# Altium schematic sheet Raijin_Connectors.SchDoc
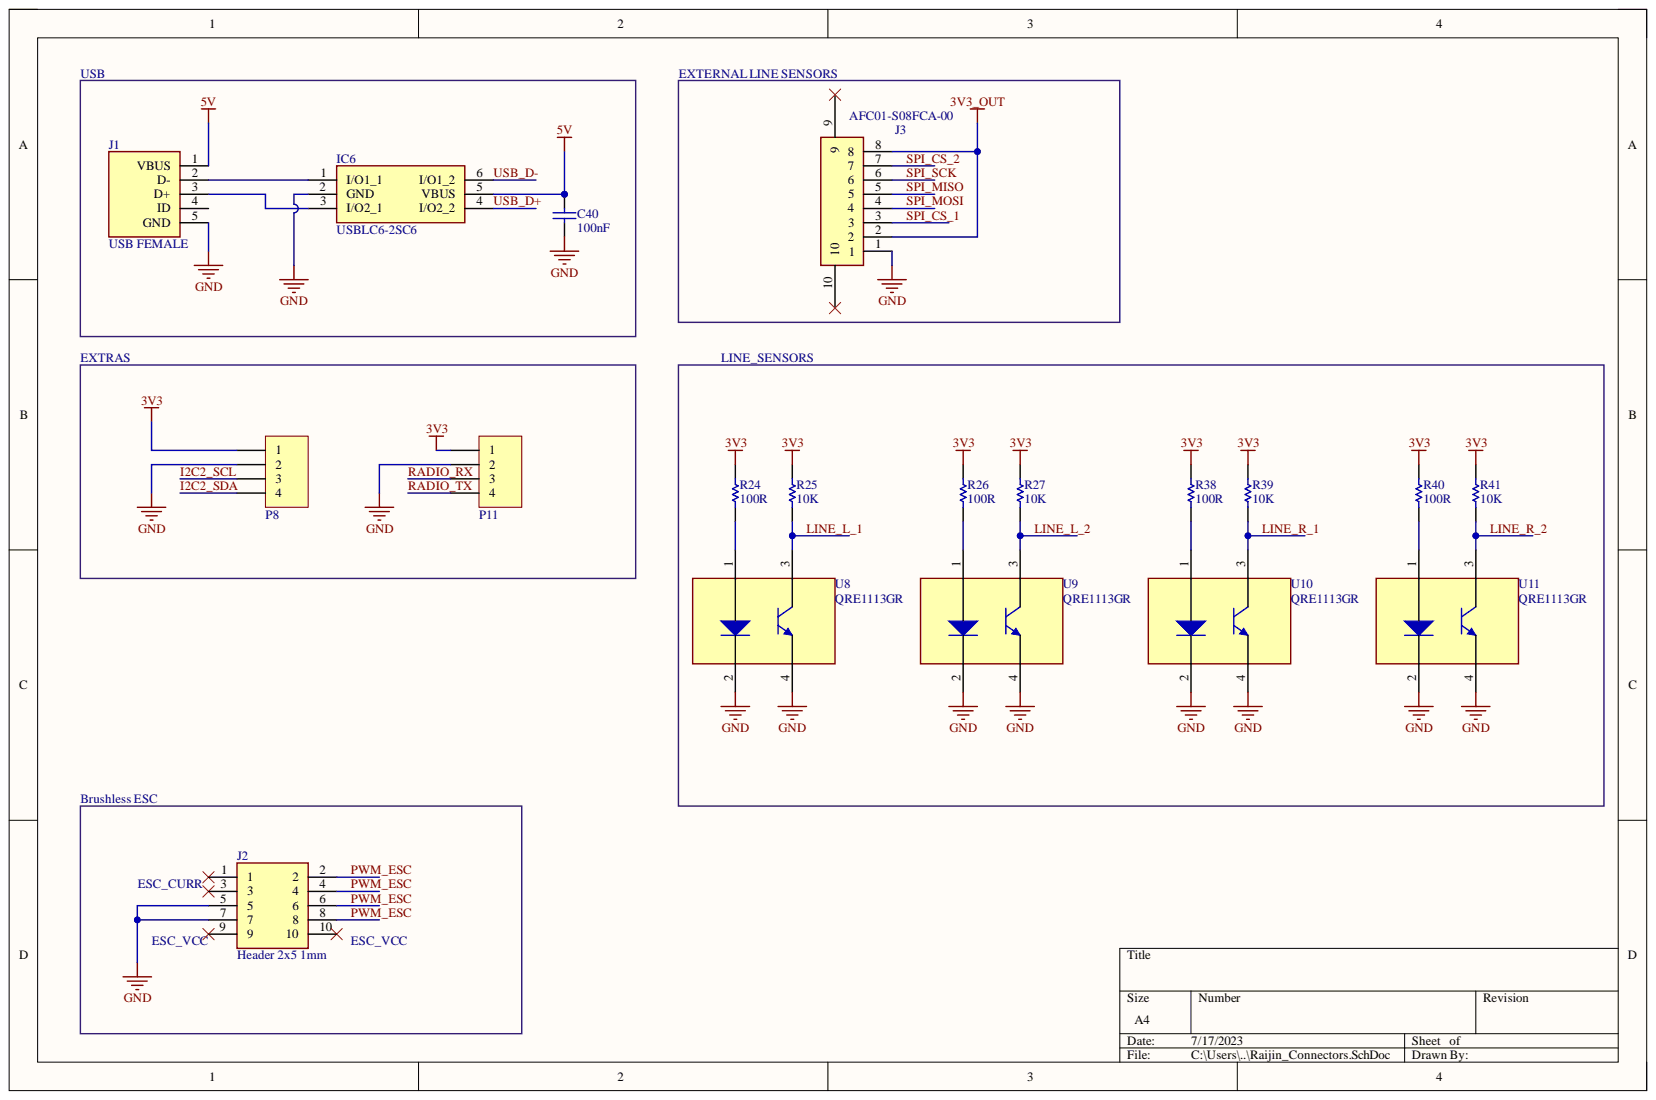
[diagram p0: Raijin_Connectors.SchDoc]

## Parts
C40  100nF  [Capacitor-0603]
IC6  USBLC6-2SC6  [SOT95P280X145-6N]
J1  USB FEMALE  [47346-0001]
J2  Header 2x5 1mm  [ESC-JHEMCU - CONNECTOR]
J3  AFC01-S08FCA-00  [FPC-SMD_P0.50-8P_FGS-XJ-H2.0]
P8  Header 1x4  [HDR1X4]  (value not drawn)
P11  Header 1x4  [HDR1X4]  (value not drawn)
R24  100R  [RESISTOR 0603]
R25  10K  [RESISTOR 0603]
R26  100R  [RESISTOR 0603]
R27  10K  [RESISTOR 0603]
R38  100R  [RESISTOR 0603]
R39  10K  [RESISTOR 0603]
R40  100R  [RESISTOR 0603]
R41  10K  [RESISTOR 0603]
U8  QRE1113GR  [QRE1113GR]
U9  QRE1113GR  [QRE1113GR]
U10  QRE1113GR  [QRE1113GR]
U11  QRE1113GR  [QRE1113GR]

## Nets
3V3: P8.1 P11.1 R24.2 R25.2 R26.2 R27.2 R38.2 R39.2 R40.2 R41.2
3V3_OUT: J3.2 J3.8
5V: C40.2 IC6.5(VBUS) J1.1(VBUS)
GND: C40.1 IC6.2(GND) J1.5(GND) J2.5 J2.7 J3.1 P8.2 P11.2 U8.2 U8.4 U9.2 U9.4 U10.2 U10.4 U11.2 U11.4
I2C2_SCL: P8.3
I2C2_SDA: P8.4
LINE_L_1: R25.1 U8.3
LINE_L_2: R27.1 U9.3
LINE_R_1: R39.1 U10.3
LINE_R_2: R41.1 U11.3
PWM_ESC: J2.2 J2.4 J2.6 J2.8
RADIO_RX: P11.3
RADIO_TX: P11.4
SPI_CS_1: J3.3
SPI_CS_2: J3.7
SPI_MISO: J3.5
SPI_MOSI: J3.4
SPI_SCK: J3.6
USB_D+: IC6.4(I/O2_2)
USB_D-: IC6.6(I/O1_2)
NetIC6_1: IC6.1(I/O1_1) J1.2(D-)
NetIC6_3: IC6.3(I/O2_1) J1.3(D+)
NetR24_1: R24.1 U8.1
NetR26_1: R26.1 U9.1
NetR38_1: R38.1 U10.1
NetR40_1: R40.1 U11.1

Not connected: J1 4(ID); J2 1 3 9 10; J3 9 10

## Library data of the parts
C40 = CAP 100NF 50V: Capacitor Cer 100nF 50V; Manufacturer Part Number: IMP14925; Capacitance: 0.1uF; Capacitance Tolerance: +80%, -20%; Capacitor Terminals: Radial Leaded; Dielectric Characteristic: Y5; Product Range: MCFY Series; SVHC: No SVHC (15-Jun-2015); Voltage Rating: 50; Capacitance Tolerance ±: +80%, -20%; Component Kind: Standard; ComponentLink1Description: http://loja.multcomercial.com.br/capacitor-ceramico-disco-100kpf-50v-104-100k-100nf-0-1uf-cod-1351.html; ComponentLink1URL: http://loja.multcomercial.com.br/capacitor-ceramico-disco-100kpf-50v-104-100k-100nf-0-1uf-cod-1351.html; Lead Spacing: 5; Manufacturer: -; Operating Temperature Max: 85; Operating Temperature Min: -25; Operating Temperature Range: -25°C to +85°C<!--FANDB--><div class="features-and-benefits-container"><div class="features-and-benefits-description"><span class="features-benefits-value">The MCFY series from Multicomp are radial leaded ceramic disc capacitors. The capacitance values ranges from 6800pF to 0.22?F.</span></div><div class="features-and-benefits-list"><ul class="features-and-benefits-list-list"><li class="features-and-benefits-list-item">Large capacitance in small size</li><li class="features-and-benefits-list-item">Rated voltage of 50V</li><li class="features-and-benefits-list-item">80%/-20% tolerance</li><li class="features-and-benefits-list-item">Operating temperature range -25°C to +85; Packaging: Each; Pricing 1: 1=0.10 (BRL); RoHS: YES; Stock 1: 115809; Supplier 1: Mult Comercial; Supplier Part Number 1: Capacitor Cerâmico Disco 100Kpf/50V (104/100K/100nF/0.1uF) cód. 1351; Library Name: RaijinLib.SchLib
IC6 = USBLC6-2SC6: Integrated Circuit; Manufacturer_Name: STMicroelectronics; Arrow Part Number: USBLC6-2SC6; Arrow Price/Stock: https://www.arrow.com/en/products/usblc6-2sc6/stmicroelectronics?region=nac; Component Kind: Standard; Datasheet Link: http://www.st.com/st-web-ui/static/active/en/resource/technical/document/datasheet/CD00050750.pdf; Height: 1.45mm; Manufacturer_Part_Number: USBLC6-2SC6; Mouser Part Number: 511-USBLC6-2SC6; Mouser Price/Stock: https://www.mouser.co.uk/ProductDetail/STMicroelectronics/USBLC6-2SC6?qs=po45yt2pPpu%2FhNIlwQdTlg%3D%3D; Library Name: RaijinLib.SchLib
J1 = USB FEMALE: Connector; Manufacturer_Name: Molex; Component Kind: Standard; Datasheet Link: http://www.molex.com/pdm_docs/sd/473460001_sd.pdf; Manufacturer_Part_Number: 47346-0001; Mouser Part Number: 538-47346-0001; Mouser Price/Stock: https://www.mouser.co.uk/ProductDetail/Molex/47346-0001?qs=c2CV6XM0DweJBWaSeyWeCw%3D%3D; Library Name: RaijinLib.SchLib
J2 = Header 2x5 1mm: Connector; Component Kind: Standard; Library Name: RaijinLib.SchLib
J3 = AFC01-S08FCA-00: FFC/FPC Connectors.   SMD,P=0.5mm, 8 pins; Manufacturer_Name: Joshuo; Component Kind: Standard; Datasheet Link: https://datasheet.lcsc.com/lcsc/2304140030_JUSHUO-AFC01-S08FCA-00_C262657.pdf; LCSC Link: https://www.lcsc.com/product-detail/FFC-FPC-Connectors_JUSHUO-AFC01-S08FCA-00_C262657.html; Manufacturer_Part_Number: AFC01-S08FCA-00; Library Name: RaijinLib.SchLib
P8 P11 = Header 1x4: Headers & Wire Housings 40Pin 2mm Spacing Single Row Hdrs, 5pc; Manufacturer Part Number: IMP10327; Brand: Cromax; Mounting Angle: Vertical; Number of Positions: 40 Position; Accessory Type: -; Category: Headers & Wire Housings; Component Kind: Standard; ComponentLink1Description: http://loja.multcomercial.com.br/barra-de-pinos-simples-1x40-macho-bmo40-1e.html; ComponentLink1URL: http://loja.multcomercial.com.br/barra-de-pinos-simples-1x40-macho-bmo40-1e.html; Contact Gender: Pin (Male); Contact Plating: Gold; Contact Type: -; Manufacturer: Cromax; Minimum Order Quantity: 1; Mounting Style: Straight Pin; Number of Rows: 1 Row; Pitch: 2 mm; Pricing 1: 1=1.00, 5=0.20 (BRL); Product: Headers; Product Category: Accessories; Reeling: False; RoHS: RoHS Compliant; RoHS - Mouser: Y; Row Spacing: -; Stock 1: 14; Supplier 1: Mult Comercial; Supplier Part Number 1: Barra de Pinos Simples 1x40 Macho BMO40-1E; Termination Style: Through Hole; Type: Breakaway; Library Name: RaijinLib.SchLib
R24 R26 R38 R40 = RES 100R 5%: Manufacturer: Ty-Ohm; Manufacturer Part Number: CR25; Power (Watts): 0.25W, 1/4W; Temperature Coefficient: 0/ -500ppm/°C; Tolerance: ±5%; Category: Resistors; Component Kind: Standard; ComponentLink1Description: http://loja.multcomercial.com.br/resistor-de-1-4-de-watt-cr25-5-de-tolerancia.html; ComponentLink1URL: http://loja.multcomercial.com.br/resistor-de-1-4-de-watt-cr25-5-de-tolerancia.html; Composition: Carbon Film; Features: Flame Retardant Coating, Safety; Height: -; Number of Terminations: 2; Operating Temperature: -55°C ~ 155°C; Package / Case: Axial; Packaging: Cut Tape (CT); Pricing 1: 1=0.20 (BRL); Resistance (Ohms): ?; RoHS: RoHS Compliant; Size / Dimension: 0.091" Dia x 0.256" L (2.30mm x 6.50mm); Stock 1: 617543; Supplier 1: Mult Comercial; Supplier Device Package: Axial; Supplier Part Number 1: Resistor de Filme de Carbono 1/4 de Watt CR25 5% de tolerância - 0.22R Ω à 10M Ω; Library Name: RaijinLib.SchLib
R25 R27 R39 R41 = RES 10K 5%: Manufacturer: PANASONIC ELECTRONIC COMPONENTS; Manufacturer Part Number: ERJ-3GEYJ103V; Power (Watts): 0.1W, 1/10W; Temperature Coefficient: ±200ppm/°C; Tolerance: ±5%; Category: Resistors; Component Kind: Standard; ComponentLink1Description: http://www.farnell.com/datasheets/1678796.pdf; ComponentLink1URL: http://www.farnell.com/datasheets/1678796.pdf; ComponentLink2Description: http://industrial.panasonic.com/www-cgi/jvcr13pz.cgi?E+PZ+3+AOA0001+ERJ3GEYJ103V+7+WW; ComponentLink2URL: http://industrial.panasonic.com/www-cgi/jvcr13pz.cgi?E+PZ+3+AOA0001+ERJ3GEYJ103V+7+WW; Composition: Thick Film; Features: Automotive AEC-Q200; Height: 0.022" (0.55mm); Number of Terminations: 2; Operating Temperature: -55°C ~ 155°C; Package / Case: 0603 (1608 Metric); Packaging: Tape & Reel (TR); Resistance (Ohms): 10k; RoHS: YES; Size / Dimension: 0.063" L x 0.031" W (1.60mm x 0.80mm); Supplier Device Package: 0603; Library Name: RaijinLib.SchLib
U8-U11 = QRE1113GR: Undefined or Miscellaneous; Manufacturer_Name: onsemi; Arrow Part Number: QRE1113GR; Arrow Price/Stock: https://www.arrow.com/en/products/qre1113gr/on-semiconductor?region=nac; Component Kind: Standard; Datasheet Link: http://www.onsemi.com/pub/Collateral/QRE1113-D.PDF; Manufacturer_Part_Number: QRE1113GR; Mouser Part Number: 512-QRE1113GR; Mouser Price/Stock: https://www.mouser.co.uk/ProductDetail/ON-Semiconductor-Fairchild/QRE1113GR?qs=Qb0BA3xILekkPpSVC5x7uQ%3D%3D; Library Name: RaijinLib.SchLib
